# Altium schematic sheet Sheet1.SchDoc(Sheet1)
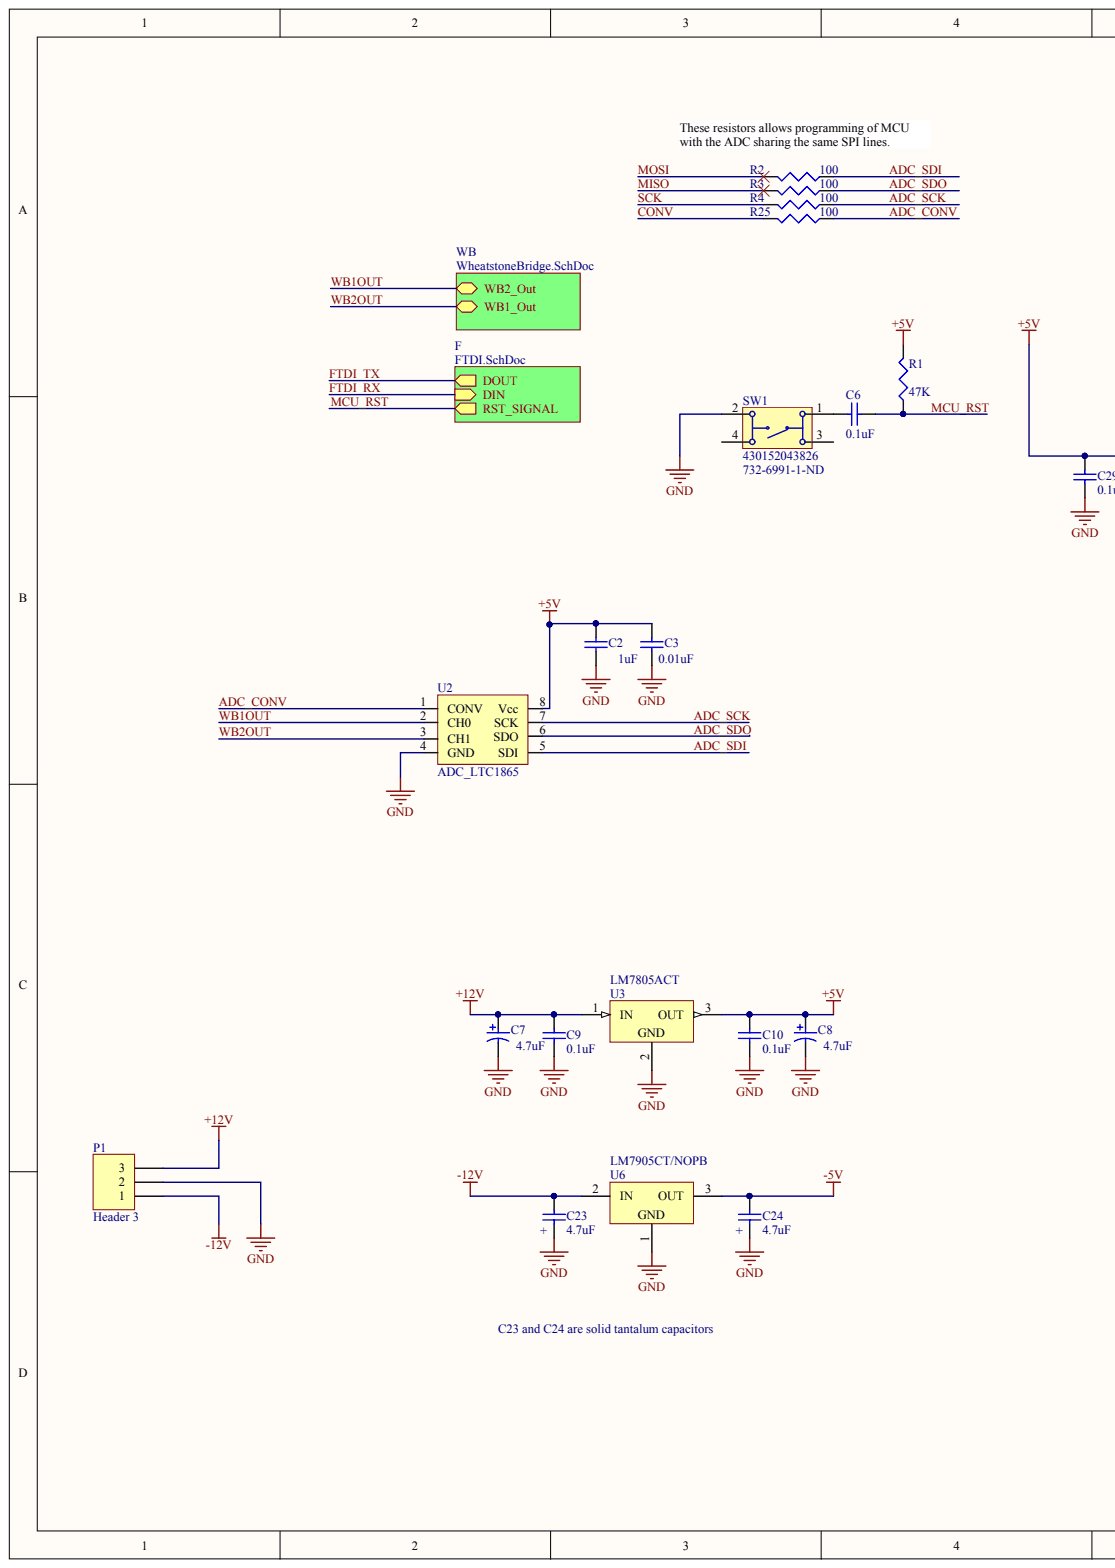
[diagram p0: Sheet1.SchDoc, left]
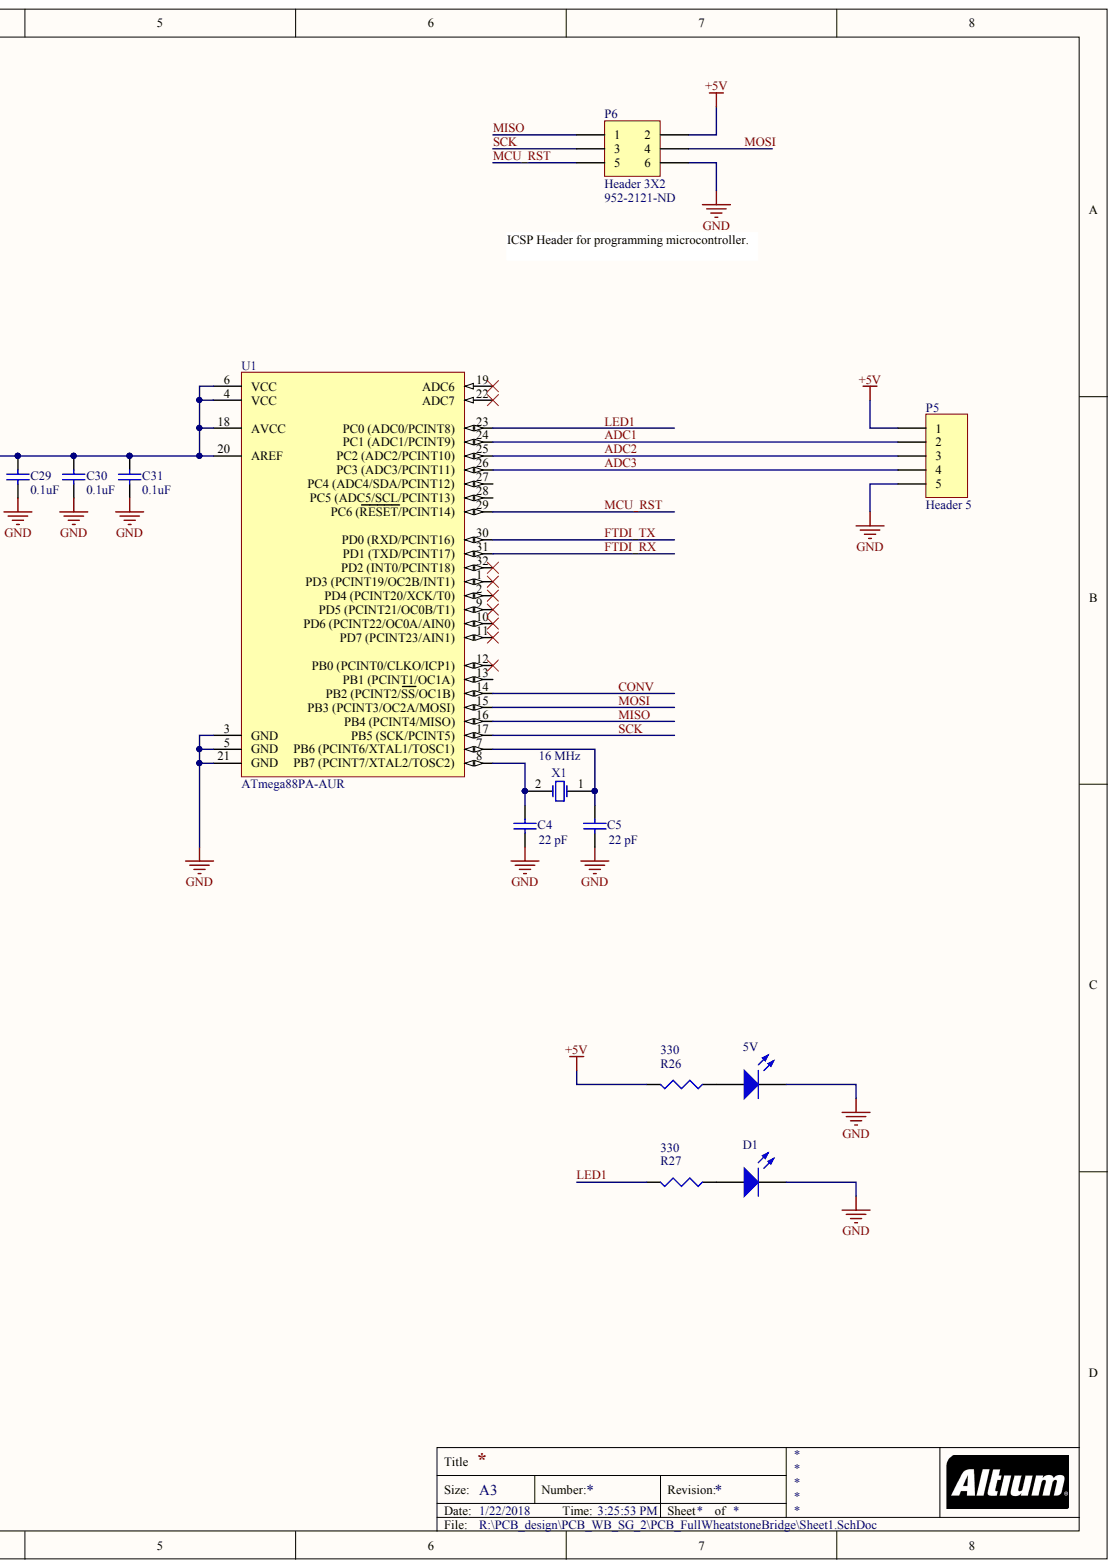
[diagram p1: Sheet1.SchDoc, right]

## Parts
5V  150060RS75000  [0603_A]  (value not drawn)
C2  value 1uF  [1608[0603]]  (hidden comment: Cap Semi)
C3  value 0.01uF  [1608[0603]]  (hidden comment: Cap Semi)
C4  value 22 pF  [1608[0603]]  (hidden comment: Cap Semi)
C5  value 22 pF  [1608[0603]]  (hidden comment: Cap Semi)
C6  value 0.1uF  [1608[0603]]  (hidden comment: Cap Semi)
C7  Capacitance: 4.7uF  [AVX-TAJ-A-2_N]  (hidden comment: TAJA475K016RNJ)
C8  Capacitance: 4.7uF  [AVX-TAJ-A-2_N]  (hidden comment: TAJA475K016RNJ)
C9  value 0.1uF  [1608[0603]]  (hidden comment: Cap Semi)
C10  value 0.1uF  [1608[0603]]  (hidden comment: Cap Semi)
C23  value 4.7uF  [1608[0603]]  (hidden comment: Cap Semi)
C24  value 4.7uF  [1608[0603]]  (hidden comment: Cap Semi)
C29  value 0.1uF  [1608[0603]]  (hidden comment: Cap Semi)
C30  value 0.1uF  [1608[0603]]  (hidden comment: Cap Semi)
C31  value 0.1uF  [1608[0603]]  (hidden comment: Cap Semi)
D1  150060RS75000  [0603_A]  (value not drawn)
P1  Header 3  [HDR1X3]
P5  Header 5  [HDR1X5]
P6  Header 3X2  Supplier Part Number 1: 952-2121-ND  [HDR2X3]
R1  value 47K  [J1-0603]  (hidden comment: Res3)
R2  value 100  [J1-0603]  (hidden comment: Res3)
R3  value 100  [J1-0603]  (hidden comment: Res3)
R4  value 100  [J1-0603]  (hidden comment: Res3)
R25  value 100  [J1-0603]  (hidden comment: Res3)
R26  value 330  [J1-0603]  (hidden comment: Res3)
R27  value 330  [J1-0603]  (hidden comment: Res3)
SW1  430152043826  Supplier Part Number 1: 732-6991-1-ND  [430152043826]
U1  ATmega88PA-AUR  [32A_N]
U2  ADC_LTC1865  [LTC1865_SOIC8]
U3  LM7805ACT  [FAIR-TO-220-3]
U6  LM7905CT/NOPB  [NDE0003A]
X1  Frequency: 16 MHz  [ABRA-ABLS2-2_V]  (hidden comment: ABLS2-16.000MHZ-D4Y-T)

## Nets
+5V: C2.1 C3.1 C8.1 C10.1 C29.1 C30.1 C31.1 P5.1 P6.2 R1.2 R26.2 U1.4(VCC) U1.6(VCC) U1.18(AVCC) U1.20(AREF) U2.8(Vcc) U3.3
+12V: C7.1 C9.1 P1.3 U3.1
-5V: C24.1 U6.3
-12V: C23.1 P1.1 U6.2
GND: 5V.1 C2.2 C3.2 C4.1 C5.1 C7.2 C8.2 C9.2 C10.2 C23.2 C24.2 C29.2 C30.2 C31.2 D1.1 P1.2 P5.5 P6.6 SW1.2 U1.3(GND) U1.5(GND) U1.21(GND) U2.4(GND) U3.2 U6.1
ADC1: P5.2 U1.24(PC1_(ADC1/PCINT9))
ADC2: P5.3 U1.25
ADC3: P5.4 U1.26(PC3_(ADC3/PCINT11))
ADC_CONV: R25.1 U2.1(CONV)
ADC_SCK: R4.1 U2.7(SCK)
ADC_SDI: R2.1 U2.5(SDI)
ADC_SDO: R3.1 U2.6(SDO)
CONV: R25.2 U1.14(PB2_(PCINT2/SS/OC1B))
FTDI_RX: U1.31(PD1_(TXD/PCINT17))
FTDI_TX: U1.30(PD0_(RXD/PCINT16))
LED1: R27.2 U1.23(PC0_(ADC0/PCINT8))
MCU_RST: C6.1 P6.5 R1.1 U1.29(PC6_(RESET/PCINT14))
MISO: P6.1 R3.2 U1.16(PB4_(PCINT4/MISO))
MOSI: P6.4 R2.2 U1.15(PB3_(PCINT3/OC2A/MOSI))
SCK: P6.3 R4.2 U1.17(PB5_(SCK/PCINT5))
WB1OUT: U2.2(CH0)
WB2OUT: U2.3(CH1)
Net5V_2: 5V.2 R26.1
NetC4_2: C4.2 U1.8 X1.2
NetC5_2: C5.2 U1.7 X1.1
NetC6_2: C6.2 SW1.1
NetD1_2: D1.2 R27.1
NetSW1_3: SW1.3
NetSW1_4: SW1.4
NetU1_1: U1.1(PD3_(PCINT19/OC2B/INT1))
NetU1_2: U1.2(PD4_(PCINT20/XCK/T0))
NetU1_9: U1.9(PD5_(PCINT21/OC0B/T1))
NetU1_10: U1.10(PD6_(PCINT22/OC0A/AIN0))
NetU1_11: U1.11(PD7_(PCINT23/AIN1))
NetU1_12: U1.12(PB0_(PCINT0/CLKO/ICP1))
NetU1_13: U1.13(PB1_(PCINT1/OC1A))
NetU1_19: U1.19(ADC6)
NetU1_22: U1.22(ADC7)
NetU1_27: U1.27(PC4_(ADC4/SDA/PCINT12))
NetU1_28: U1.28(PC5_(ADC5/SCL/PCINT13))
NetU1_32: U1.32(PD2_(INT0/PCINT18))

## Library data of the parts
5V D1 = CMP-1426-00001-1: SMD mono-color Chip LED, WL-SMCW, Red; Manufacturer: Wuerth Elektronik; Color: Red; Component Kind: Standard; ComponentLink1Description: Manufacturer URL; ComponentLink1URL: http://www.we-online.com; ComponentLink2Description: Datasheet; ComponentLink2URL: http://katalog.we-online.com/led/datasheet/150060RS75000.pdf; Library Name: Altium Content Vault; PackageReference: 0603_A; PartNumber: 150060RS75000; Supplier 1: Digi-Key; Supplier Part Number 1: 732-4978-1-ND
C2-C6 C9 C10 C23 C24 C29-C31 = Cap Semi: Capacitor (Semiconductor SIM Model); Component Kind: Standard; LatestRevisionDate: 29-May-2009; LatestRevisionNote: IPC-7351 Footprint Added.; Library Name: Miscellaneous Devices.IntLib; PackageDescription: Chip Capacitor; PackageReference: C1206; Published: 8-Jun-2000; Signal Integrity: Cap Semi; Simulation: CAP; Supplier 1: Digi-Key; Publisher: Altium Limited
  C2 C3 C6 C9 C10 C23 C24 C29-C31: Supplier Part Number 1: 490-10901-1-ND
  C4 C5: Supplier Part Number 1: 478-1166-1-ND
C7 C8 = CMP-2100-03687-1: Standard Tantalum, 4.7 uF, +/- 10%, 16 V, -55 to 125 degC, 2-Pin SMD (1206), RoHS, Tape and Reel; Manufacturer: AVX; Dissipation Factor: 6%; ESR (Equivalent Series Resistance): 4Ohm; Leakage Current: 800nA; Polarity: Polar; Voltage: 16V; Case/Package: 1206; Case Code (Imperial): 1206; Case Code (Metric): 3216; Component Kind: Standard; Datasheet URL: http://datasheets.avx.com/TAJ.pdf; Datasheet Version: 42401.0; Depth: 1.6mm; Dielectric Material: Tantalum; Height: 1.6mm; Height - Seated (Max): 0.071inch; Lead Free: LeadFree; Lead Pitch: 1.1mm; Length: 3.2mm; Library Name: Altium Content Vault; Manufacturer URL: http://www.avx.com/; Max Operating Temperature: 125degC; Min Operating Temperature: -55degC; Number of Pins: 2; Package Description: 2-Pin Surface Mount Device, Body 3.2 x 1.65 mm; Package Quantity: 1; Package Reference: TAJ-A-2; Packaging: TapeandReel; Radiation Hardening: No; REACH SVHC: NoSVHC; Resistance: 4Ohm; RoHSCompliant: True; Termination: SMD/SMT; Tolerance: 10%; Voltage Rating: 16V; Voltage Rating (DC): 16V; Width: 1.6mm
P1 = Header 3: Header, 3-Pin; Component Kind: Standard; LatestRevisionDate: 17-Jul-2002; LatestRevisionNote: Re-released for DXP Platform.; Library Name: Miscellaneous Connectors.IntLib; Signal Integrity: Connector; Publisher: Altium Limited
P5 = Header 5: Header, 5-Pin; Component Kind: Standard; LatestRevisionDate: 17-Jul-2002; LatestRevisionNote: Re-released for DXP Platform.; Library Name: Miscellaneous Connectors.IntLib; Signal Integrity: Connector; Publisher: Altium Limited
P6 = Header 3X2: Header, 3-Pin, Dual row; Component Kind: Standard; LatestRevisionDate: 17-Jul-2002; LatestRevisionNote: Re-released for DXP Platform.; Library Name: Miscellaneous Connectors.IntLib; Signal Integrity: Connector; Supplier 1: Digi-Key; Publisher: Altium Limited
R1-R4 R25-R27 = Res3: Resistor; Component Kind: Standard; LatestRevisionDate: 29-May-2009; LatestRevisionNote: IPC-7351 Footprint Added.; Library Name: Miscellaneous Devices.IntLib; PackageDescription: Chip Resistor; PackageReference: J1-0603; Published: 8-Jun-2000; Signal Integrity: Res; Simulation: RESISTOR; Supplier 1: Digi-Key; Publisher: Altium Limited
  R1: Supplier Part Number 1: 311-47.0KHRCT-ND
  R2-R4 R25: Supplier Part Number 1: 311-100HRCT-ND
  R26 R27: Supplier Part Number 1: 311-330HRCT-ND
SW1 = CMP-1452-00001-1: WS-TASV SMD Tact Switch 6X6 mm; Manufacturer: Wuerth Elektronik; NoPins: 04; Component Kind: Standard; ComponentLink1Description: Manufacturer URL; ComponentLink1URL: http://www.we-online.com; ComponentLink2Description: Datasheet; ComponentLink2URL: http://katalog.we-online.de/em/datasheet/4301x2.pdf; Library Name: Altium Content Vault; PackageReference: 430152043826; PartNumber: 430152043826; Supplier 1: Digi-Key
U1 = CMP-0095-00212-2: 8-bit AVR Microcontroller, 8KB Flash, 512 Bytes EEPROM, 1KB SRAM, 32-pin TQFP, Industrial Grade (-40°C to 85°C), Reel; Code_JEDEC: MS-026-ABA; Component Kind: Standard; ComponentLink1Description: Manufacturer URL; ComponentLink1URL: http://www.atmel.com/; ComponentLink2Description: ATmega48A/48PA/88A/88PA/168A/168PA/328/328P Datasheet; ComponentLink2URL: http://www.atmel.com/dyn/resources/prod_documents/doc8271.pdf; ComponentLink3Description: ATmega48A/48PA/88A/88PA/168A/168PA/328/328P Summary; ComponentLink3URL: http://www.atmel.com/dyn/resources/prod_documents/8271S.pdf; DatasheetVersion: revD, May-2011; Library Name: Altium Content Vault; PackageDescription: 32-Lead, 7x7x1.0 mm Body, 0.8mm Lead Pitch, Thin Profile Plastic Quad Flat Package (TQFP); PackageReference: 32A; PackageVersion: revC, Oct-2010; RevisionNote: DatasheetVersion & PackageVersion updated. URLs added.
U3 = CMP-2000-04937-1: Positive Voltage Regulator, 5 V, 1 A, 0 to 125 degC, 3-Pin TO-220, RoHS, Tube; Manufacturer: Fairchild; Dropout Voltage Measurement Current: 1A; Line Regulation: 50mV; Load Regulation: 100mV; Maximum Input Voltage: 35V; Number of Outputs: 1; Output Type: Fixed; Output Voltage: 5V; Quiescent Current: 6mA; Regulator Function: Linear; Typical Dropout Voltage @ Current: 2V@1A; Component Kind: Standard; Datasheet URL: https://datasheet.ciiva.com/5816/1359194-5816420.pdf; Datasheet Version: Rev. 1.0.1, 05/2006; Dimensions: 10.67x4.83x16.51mm; Height: 16.51mm; Length: 10.67mm; Library Name: Altium Content Vault; Manufacturer URL: https://www.fairchildsemi.com/; Maximum Operating Temperature: +125degC; Maximum Output Current: 1A; Minimum Operating Temperature: 0degC; Mounting Type: ThroughHole; Package Description: 3-Pin Through Hole Device, Body 10 x 4.5 mm, Pitch 2.54 mm; Package Reference: TO-220; Package Type: TO-220; Package URL: https://www.fairchildsemi.com/package-drawings/TO/TO220B03.pdf; Pin Count: 3; Polarity: Positive; Series: LM78xx; Width: 4.83mm
U6 = CMP-0062-02481-3: 3-Terminal Negative Regulator, 3-pin TO-220, Pb-Free; Manufacturer: Texas Instruments; CompType: LDO IC; PackageTopMarking: NSUZXYTTE#*LM7905CT; PowerWise: N; Component Kind: Standard; ComponentLink1Description: Datasheet URL; ComponentLink1URL: http://www.ti.com/general/docs/lit/getliterature.tsp?genericPartNumber=LM7905&fileType=pdf; Library Name: Altium Content Vault; PackageDescription: TO-220, Molded, 3 Lead, Straight; PackageReference: NDE0003A; PinCount: 3; RoHS: Y
X1 = CMP-0277-00002-1: Low Profile Surface Mount Microprocessor Crystal, 16.000 MHz +/-30 ppm, 180 Ohm, -40 to 85 degC, 2-Pin 11.4 x 4.7 x 3.3 mm SMD, RoHS, Tape and Reel; Manufacturer: Abracon; ESR: 180 Ohm; Load Capacitance: 18 pF; Operation Mode: Fundamental or 3rd Overtone; Shunt Capacitance: 7 pF Max.; Component Kind: Standard; ComponentLink1Description: Manufacturer URL; ComponentLink1URL: http://www.abracon.com/; ComponentLink2Description: Datasheet; ComponentLink2URL: http://www.abracon.com/Resonators/ABLS2.pdf; DatasheetVersion: Rev. 03.31.11; Freq. Stability: +/- 30 ppm; Freq. Tolerance: +/- 30 ppm; Library Name: Altium Content Vault; Mounting Technology: Surface Mount; Operating Temp. Range: -40 to 85 degC; PackageDescription: 2-Pin SMD, Body 11.4 x 4.7 mm, Height 3.3 mm; PackageReference: ABLS2-2; Packing: Tape and Reel; PartNumber: ABLS2-16.000MHZ-D4Y-T; RoHS: TRUE; Supplier 1: Digi-Key; Supplier Part Number 1: 535-9875-1-ND
U2 = ADC_LTC1865: Component Kind: Standard; Library Name: Schlib1.SchLib
